# Altium schematic sheet Regulator_9V0_to_5V0.SchDoc(Regulator_9V0_to_5V0)
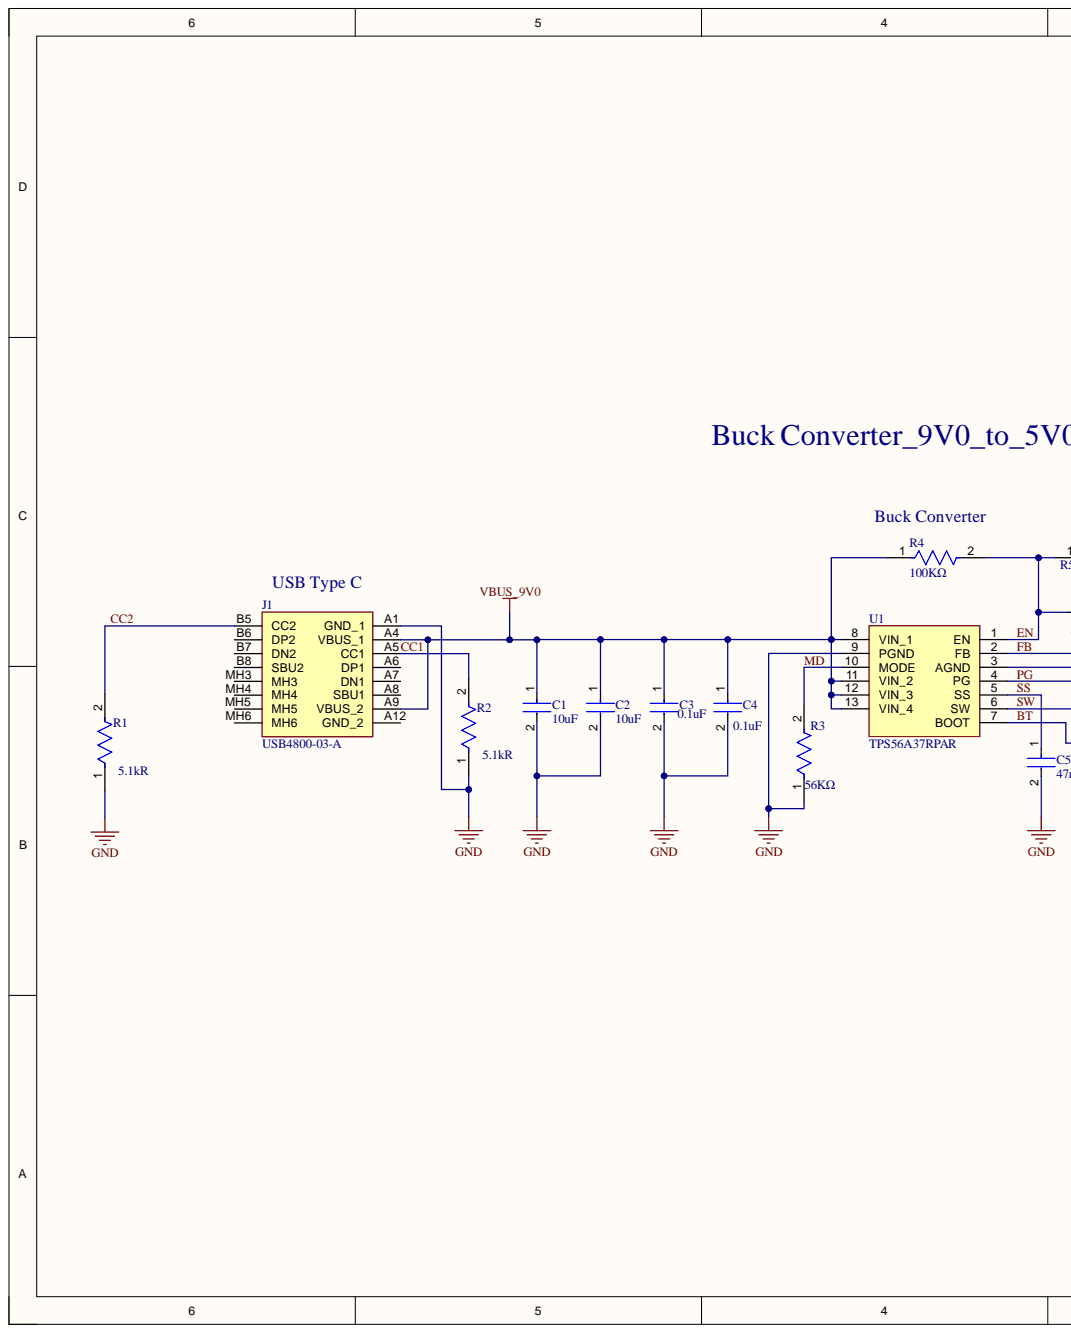
[diagram p0: Regulator_9V0_to_5V0.SchDoc, left]
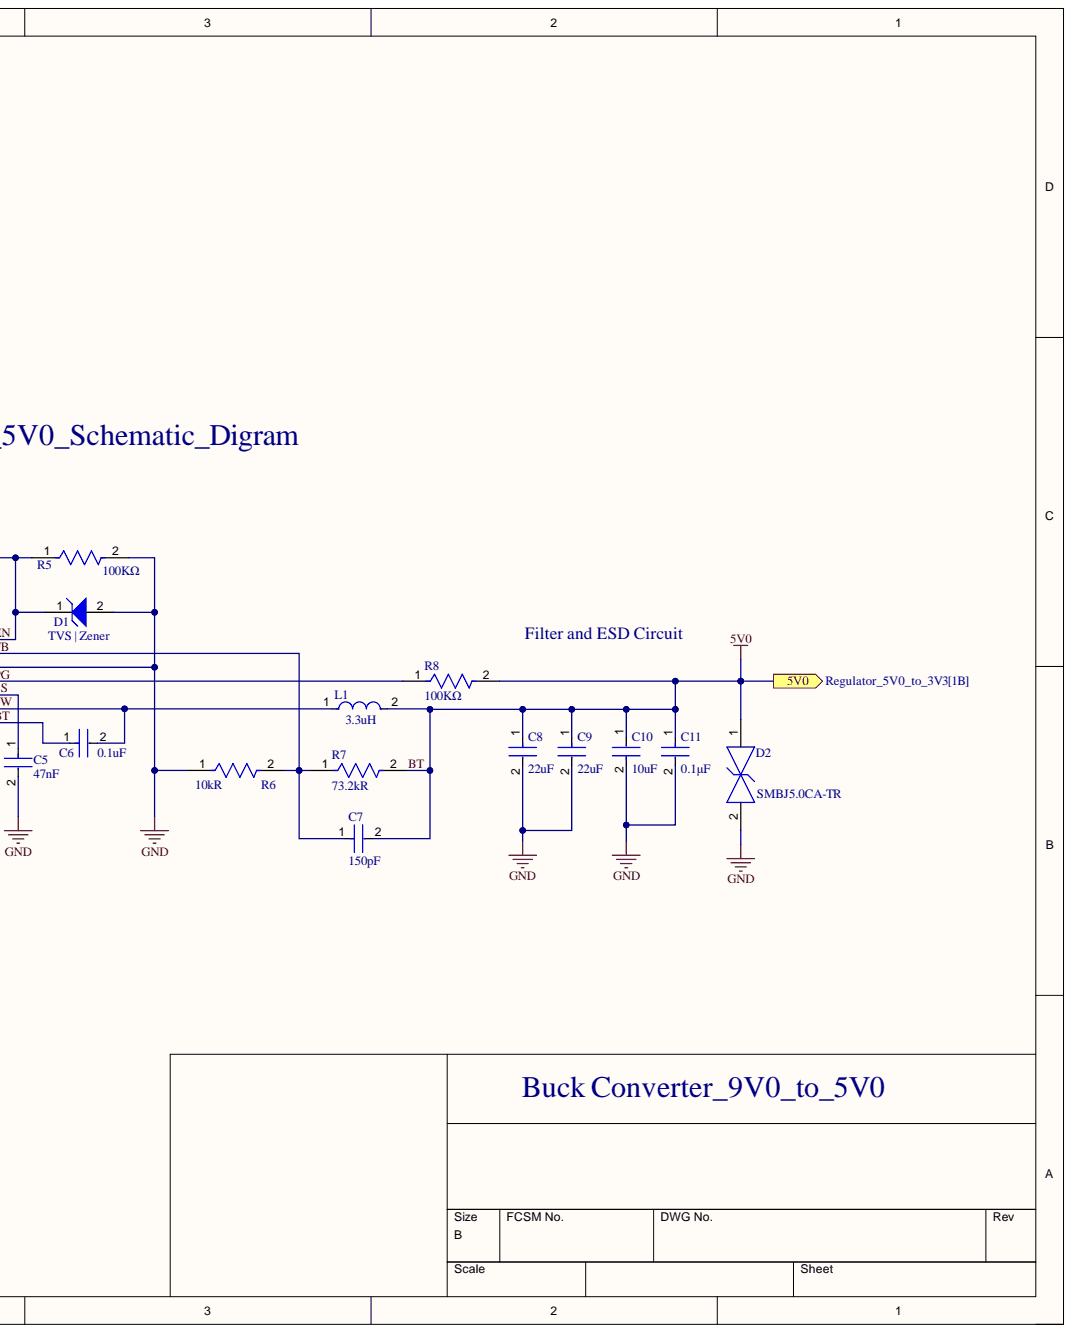
[diagram p1: Regulator_9V0_to_5V0.SchDoc, right]

## Parts
C1  Capacitance: 10uF  [FP-CL21-IPC_C]  (hidden comment: CL21A106KOQNNNG)
C2  Capacitance: 10uF  [FP-CL21-IPC_C]  (hidden comment: CL21A106KOQNNNG)
C3  Capacitance: 0.1uF  [FP-0402-L_1_0_1-W_0_5_0_1-IPC_B]  (hidden comment: 0402YD104KAT2A)
C4  Capacitance: 0.1uF  [FP-0402-L_1_0_1-W_0_5_0_1-IPC_B]  (hidden comment: 0402YD104KAT2A)
C5  Capacitance: 47nF  [FP-CL579-IPC_C]  (hidden comment: CL05B473KO5NNNC)
C6  Capacitance: 0.1uF  [FP-0402-L_1_0_1-W_0_5_0_1-IPC_B]  (hidden comment: 0402YD104KAT2A)
C7  Capacitance: 150pF  [FP-C1005-050-0_05-IPC_B]  (hidden comment: C1005NP01H151J050BA)
C8  Capacitance: 22uF  [FP-CL10-IPC_B]  (hidden comment: CL10A226MO7JZNC)
C9  Capacitance: 22uF  [FP-CL10-IPC_B]  (hidden comment: CL10A226MO7JZNC)
C10  Capacitance: 10uF  [FP-C1608-080-0_1-IPC_A]  (hidden comment: C1608X5R1A106M080AC)
C11  Capacitance: 0.1μF  [FP-C0603C-CF-MFG]  (hidden comment: C0603C104K8RACTU)
D1  ESD5Z6.0T1G  [ONSC-SOD-523-2-502-01_V]  (value not drawn)
D2  SMBJ5.0CA-TR  [FP-SMB-CA-MFG]
J1  USB4800-03-A  [USB480003A]
L1  Inductance: 3.3uH  [FP-IHLP-2020CZ-FP_1_905x2-MFG]  (hidden comment: IHLP2020CZER3R3M11)
R1  Resistance: 5.1kR  [FP-CRCW0402-e3-IPC_C]  (hidden comment: CRCW04025K10FKED)
R2  Resistance: 5.1kR  [FP-CRCW0402-e3-IPC_C]  (hidden comment: CRCW04025K10FKED)
R3  value 56KΩ  Resistance: 56KΩ  [FP-CRGCQ0402-IPC_C]  (hidden comment: CRGCQ0402F56K)
R4  value 100KΩ  Resistance: 100KΩ  [FP-CRGP0402-IPC_C]  (hidden comment: CRGP0402F100K)
R5  value 100KΩ  Resistance: 100KΩ  [FP-CRGP0402-IPC_C]  (hidden comment: CRGP0402F100K)
R6  Resistance: 10kR  [FP-AC0402-MFG]  (hidden comment: AC0402FR-0710KL)
R7  Resistance: 73.2kR  [FP-RC1005-IPC_B]  (hidden comment: RC1005F7322CS)
R8  value 100KΩ  Resistance: 100KΩ  [FP-CRGP0402-IPC_C]  (hidden comment: CRGP0402F100K)
U1  TPS56A37RPAR  [TPS56A37RPAR]

## Ports
5V0

## Nets
GND: C1.2 C2.2 C3.2 C4.2 C5.2 C8.2 C9.2 C10.2 C11.2 D1.2 D2.2 J1.A1(GND_1) R1.1 R2.1 R3.1 R5.2 R6.1 U1.3(AGND) U1.9(PGND)
VBUS_9V0: C1.1 C2.1 C3.1 C4.1 J1.A4(VBUS_1) J1.A9(VBUS_2) R4.1 U1.8(VIN_1) U1.11(VIN_2) U1.12(VIN_3) U1.13(VIN_4)
BT: C6.1 C7.2 C8.1 C9.1 C10.1 C11.1 D2.1 L1.2 R7.2 R8.2 U1.7(BOOT) ; port 5V0
CC1: J1.A5(CC1) R2.2
CC2: J1.B5(CC2) R1.2
EN: D1.1 R4.2 R5.1 U1.1(EN)
FB: C7.1 R6.2 R7.1 U1.2(FB)
MD: R3.2 U1.10(MODE)
PG: R8.1 U1.4(PG)
SS: C5.1 U1.5(SS)
SW: C6.2 L1.1 U1.6(SW)

Not connected: J1 A6(DP1) A7(DN1) A8(SBU1) A12(GND_2) B6(DP2) B7(DN2) B8(SBU2) MH3 MH4 MH5 MH6

## Library data of the parts
C1 C2 = CMP-13271-002240-1: CL21 Series 0805 10uF 16V ±10% Tolerance X5R Multilayer Ceramic Chip Capacitor; Case Code (Imperial): 0805; Case Code (Metric): 2012; Case/Package: 0805; Component Kind: Standard; Depth: 1.25mm; Dielectric: X5R; Height: 1.25mm; Length: 2mm; Library Name: Altium Content Vault; Material: Ceramic; Max Operating Temperature: 85°C; Min Operating Temperature: -55°C; Mount: Surface Mount; Package Quantity: 3000; Packaging: Tape and Reel; Thickness: 0.053inch; Tolerance: 10%; Voltage: 16V; Voltage Rating: 16V; Width: 0.049inch
C3 C4 C6 = CMP-2008-02842-2: General Purpose Ceramic Capacitor, 0402, 10uF, 10%, X5R, 15%, 16V; Dissipation Factor: 3.5%; Case Code (Imperial): 0402; Case Code (Metric): 1005; Case/Package: 0402; Component Kind: Standard; Construction: Flat; Contact Plating: Tin; Depth: 0.5mm; Dielectric: X5R; Height: 0.56mm; Lead Free: Lead Free; Length: 1mm; Library Name: Altium Content Vault; Max Operating Temperature: 85°C; Min Operating Temperature: -55°C; Mount: Surface Mount; Package Quantity: 1; Packaging: Tape and Reel; Radiation Hardening: No; REACH SVHC: No SVHC; RoHS Compliant: Yes; Series: -; Termination: SMD/SMT; Thickness: 0.022inch; Tolerance: 10%; Voltage: 16V; Voltage Rating: 16V; Voltage Rating (DC): 16V; Width: 0.02inch
C5 = CMP-13271-000643-1: Cap Ceramic 47nF 16V X7R ±10% SMD 0402 +125°C Paper T/R; Case Code (Imperial): 0402; Case Code (Metric): 1005; Case/Package: 0402; Component Kind: Standard; Contact Plating: Tin; Depth: 0.5mm; Dielectric: X7R; Height: 0.5mm; Lead Free: Lead Free; Length: 1mm; Library Name: Altium Content Vault; Max Operating Temperature: 125°C; Min Operating Temperature: -55°C; Mount: Surface Mount; Package Quantity: 1; Packaging: Tape and Reel; Radiation Hardening: No; Thickness: 0.022inch; Tolerance: 10%; Voltage: 16V; Voltage Rating: 16V; Voltage Rating (DC): 16V; Width: 0.02inch
C7 = CMP-08246-007033-1: Multilayer Ceramic Capacitors 150pF ±5% 50V NP0 SMD 0402; Dielectric: C0G; Case Code (Imperial): 0402; Case Code (Metric): 1005; Case/Package: 0402; Component Kind: Standard; Features: High Temperature; Height: 0.5mm; Length: 0.039inch; Library Name: Altium Content Vault; Max Operating Temperature: 150°C; Min Operating Temperature: -55°C; Mount: Surface Mount; Package Quantity: 10000; Packaging: Tape and Reel; RoHS Compliant: Yes; Series: C; Termination: SMD/SMT; Thickness: 0.022inch; Tolerance: 5%; Voltage Rating: 50V; Voltage Rating (DC): 50V; Weight: 2.3E-05oz; Width: 0.02inch
C8 C9 = CMP-13271-002755-1: Cap Ceramic 22uF 16V X5R ±20% Pad SMD 0603 +85°C T/R; Case Code (Imperial): 0603; Case Code (Metric): 1608; Case/Package: 0603; Component Kind: Standard; Library Name: Altium Content Vault; Max Operating Temperature: 85°C; Min Operating Temperature: -55°C; Mount: Surface Mount; Packaging: Tape and Reel; Tolerance: 20%; Voltage Rating: 16V
C10 = CMP-2000-04980-2: Multilayer Ceramic Capacitors 10μF ±20% 10V X5R SMD 0603; Case Code (Imperial): 0603; Case Code (Metric): 1608; Case/Package: 0603; Component Kind: Standard; Construction: Flat; Depth: 0.8mm; Dielectric: X5R; Dielectric Material: Ceramic; Height: 0.8mm; Lead Free: Lead Free; Length: 1.6mm; Library Name: Altium Content Vault; Max Operating Temperature: 85°C; Min Operating Temperature: -55°C; Mount: Surface Mount; Number of Pins: 2; Package Quantity: 1; Packaging: Tape and Reel; Radiation Hardening: No; REACH SVHC: No SVHC; Resistor Type: Ceramic; RoHS Compliant: Yes; Series: C; Termination: SMD/SMT; Thickness: 0.035inch; Tolerance: 20%; Voltage Rating: 10V; Voltage Rating (DC): 10V; Weight: 7.1E-05oz; Width: 0.031inch
C11 = CMP-1035-02580-3: CAP CER 0.1UF 10V X7R 0603; Manufacturer: KEMET; Automotive Grade: Grade 1; Material: Ceramic; Temperature Coefficient: 30ppm/°C; Tolerance: 0.1; Value: 0.10 μF; Automotive: Yes; Category: Cap; Component Kind: Standard; ComponentLink2Description: Datasheet; ComponentLink2URL: https://api.kemet.com/component-edge/download/datasheet/C0603C100J5GACAUTO.pdf; Device Class L1: Passive Components; Device Class L2: Capacitors; Device Class L3: Ceramic Capacitors; Digikey Description: CAP CER 0.1UF 10V X7R 0603; Height: 0.87mm; Lead Free: Yes; Library Name: Altium Content Vault; Manufacturer Part Number: C0603C104K8RACTU; Mouser Description: Multilayer Ceramic Capacitors MLCC - SMD/SMT 10volts 0.1uF X7R 10%; Octopart: https://octopart.com/c0603c104k8ractu-kemet-145075; Package: C0603C-CF; RoHS: TRUE; Temperature: -55°C to +125°C; Temperature Characteristic: X7R; Temperature Range High: +125°C; Temperature Range Low: -55°C; Voltage Rating: 10 V
D1 = CMP-1063-00101-1: Transient Voltage Suppressor, Micro-Packaged Diode for ESD Protection, 2-Pin SOD-523, Pb-Free, Tape and Reel; Manufacturer: On Semiconductor; Direction: Unidirectional; IR Max (uA): 0.01; Number of Lines: 1; PPK Max (W): 181; V(BR) Min (V): 6.8; VRWM Max (V): 6; Component Kind: Standard; ComponentLink1Description: Manufacturer URL; ComponentLink1URL: http://www.onsemi.com/; ComponentLink2Description: Datasheet; ComponentLink2URL: http://www.onsemi.cn/pub_link/Collateral/ESD5Z2.5T1-D.PDF; ComponentLink3Description: Package Specification; ComponentLink3URL: http://www.onsemi.com/pub_link/Collateral/502-01.PDF; DatasheetVersion: Rev. 10; Library Name: Altium Content Vault; Mounting Technology: Surface Mount; PackageDescription: 2-Pin SOD, Body 1.2 x 0.8 mm; PackageReference: SOD-523-2-502-01; PackageVersion: Rev. E, 09/2010; Packing: Tape and Reel; PartNumber: ESD5Z6.0T1G; RoHS: Pb-Free
D2 = CMP-12225-000020-1: TVS DIODE 5V 13.4V SMB; Manufacturer: STMicroelectronics; Breakdown Voltage: 6.4V; Clamping Voltage: 9.2V; Direction: Bidirectional; Max Junction Temp: +150°C; Number Of Channels: 1; Peak Pulse Current: 68A; Peak Pulse Power: 600W; Standoff Height: 0.05mm; Automotive: No; Category: Diode; Component Kind: Standard; ComponentLink2Description: Datasheet; ComponentLink2URL: https://www.st.com/content/ccc/resource/technical/document/datasheet/19/78/91/39/07/cd/40/65/CD00001366.pdf/files/CD00001366.pdf/_jcr_content/translations/en.CD00001366.pdf; Device Class L1: Circuit Protection; Device Class L2: TVS Diodes; Device Class L3: unset; Digikey Description: TVS DIODE 5V 13.4V SMB; Height: 2.65mm; Lead Free: Yes; Library Name: Altium Content Vault; Manufacturer Part Number: SMBJ5.0CA-TR; Mouser Description: TVS Diodes - Transient Voltage Suppressors 600W 5.0V Bidirect; Octopart: https://octopart.com/smbj5.0ca-tr-stmicroelectronics-347080; Package: SMB-CA; Reverse Standoff Voltage: 5V; RoHS: TRUE; Temperature: -55°C to +150°C; Temperature Range High: +150°C; Temperature Range Low: -55°C
J1 = USB4800-03-A: Connector; Manufacturer_Name: GCT (GLOBAL CONNECTOR TECHNOLOGY); Component Kind: Standard; Datasheet Link: https://gct.co/connector/usb4800; Height: 5.58mm; Library Name: USB480003A.IntLib; Manufacturer_Part_Number: USB4800-03-A; Mouser Part Number: 640-USB4800-03-A; Mouser Price/Stock: https://www.mouser.co.uk/ProductDetail/GCT/USB4800-03-A?qs=vvQtp7zwQdMkfG8vViEZoQ%3D%3D
L1 = CMP-02424-000463-1: Fixed Inductors Commercial Low DCR Series 3.3uH 5.5A 40.4mΩ 20%; DC Resistance (DCR): 40.4mR; Max DC Current: 7A; Self Resonant Frequency: 29MHz; Shielding: Shielded; Test Frequency: 100kHz; Case/Package: 2020; Component Kind: Standard; Contact Plating: Tin; Current Rating: 5.5A; Depth: 5.43mm; Height: 3mm; Height - Seated (Max): 0.118inch; Inductance Tolerance: 20%; Lead Free: Lead Free; Length: 5.74mm; Library Name: Altium Content Vault; Max Operating Temperature: 125°C; Military Standard: Not; Min Operating Temperature: -55°C; Mount: Surface Mount; Package Quantity: 2000; Packaging: Tape and Reel; Radiation Hardening: No; RMS Current (Irms): 5.5A; Saturation Current: 7A; Termination: SMD/SMT; Tolerance: 20%; Width: 0.204inch
R1 R2 = CMP-1011-00590-4: RES Thick Film, 5.1kΩ, 1%, 0.063W, 100ppm/°C, 0402; Max Power Dissipation: 63mW; Voltage Rating: 50V; Case Code (Imperial): 0402; Case Code (Metric): 1005; Case/Package: 0402; Component Kind: Standard; Composition: Thick Film; Contact Plating: Tin; Depth: 0.5mm; Features: Automotive AEC-Q200; Height: 0.35mm; Lead Free: Lead Free; Length: 1mm; Library Name: Altium Content Vault; Max Operating Temperature: 155°C; Military Standard: Not; Min Operating Temperature: -55°C; Number of Terminations: 2; Package Quantity: 10; Packaging: Tape and Reel; Power Rating: 63mW; Radiation Hardening: No; Temperature Coefficient: 100ppm/°C; Termination: SMD/SMT; Tolerance: 1%; Voltage Rating (DC): 50V; Weight: 2.3E-05oz; Width: 0.02inch
R3 = CMP-03211-009184-1: CRGCQ 0402 56K 1%; Manufacturer: TE Connectivity; Power Rating: 0.0625W; Temperature Coefficient: ±100ppm/°C; Tolerance: 0.01; Voltage Rating: 50V; Ambient Temperature Range High: +70°C; Automotive: Yes; Category: Res; Component Kind: Standard; ComponentLink2Description: Datasheet; ComponentLink2URL: https://www.te.com/commerce/DocumentDelivery/DDEController?Action=showdoc&DocId=Data+Sheet%7F1773204-3%7F1%7Fpdf%7FEnglish%7FENG_DS_1773204-3_1.pdf%7F4-2176347-1092; Composition: Thick Film; Device Class L1: Passive Components; Device Class L2: Resistors; Device Class L3: Chip SMD Resistors; Digikey Description: CRGCQ 0402 56K 1%; Height: 0.4mm; Ipc Land Pattern Name: RESC10050X35; Lead Free: Yes; Library Name: Altium Content Vault; Manufacturer Part Number: CRGCQ0402F56K; Octopart: https://octopart.com/crgcq0402f56k-te+connectivity-91017978; Package: CRGCQ0402; RoHS: TRUE; Series: CRGCQ; Temperature: -55°C to +155°C; Temperature Range High: +155°C; Temperature Range Low: -55°C
R4 R5 R8 = CMP-03211-001392-1: SMD Precision Pulse Thick Film Chip Resistor; Manufacturer: TE; Power Rating: 0.125W; Temperature Coefficient: ±100ppm/°C; Tolerance: 0.01; Voltage Rating: 50V; Automotive: No; Category: Res; Component Kind: Standard; ComponentLink2Description: Datasheet; ComponentLink2URL: https://www.mouser.ca/datasheet/2/418/NG_DS_9-1773463-9_A-1358566.pdf; Composition: Thick Film; Device Class L1: Passive Components; Device Class L2: Resistors; Device Class L3: Chip SMD Resistors; Digikey Description: SMD Precision Pulse Thick Film Chip Resistor; Height: 0.4mm; Ipc Land Pattern Name: RESC11050X35; Lead Free: Yes; Library Name: Altium Content Vault; Manufacturer Part Number: CRGP0402F100K; Octopart: https://octopart.com/crgp0402f100k-te+connectivity-91018658; Package: CRGP0402; RoHS: TRUE; Series: CRGP; Temperature: -55°C to +155°C; Temperature Range High: +155°C; Temperature Range Low: -55°C
R6 = CMP-2002-01790-3: RES 10kΩ ±1% 0.063W 0402; Max Power Dissipation: 63mW; Power Rating: 62.5mW; Temperature Coefficient: 100ppm/°C; Voltage Rating: 50V; Case Code (Imperial): 0402; Case Code (Metric): 1005; Case/Package: 0402; Component Kind: Standard; Construction: Rectangular; Depth: 0.5mm; Features: Moisture Resistant; Height: 0.015inch; Lead Free: Lead Free; Length: 0.039inch; Library Name: Altium Content Vault; Max Operating Temperature: 155°C; Military Standard: Not; Min Operating Temperature: -55°C; Number of Terminations: 2; Packaging: Tape and Reel; Resistor Type: Thick Film; RoHS Compliant: Yes; Series: AC; Size Code: 0402; Termination: SMD/SMT; Tolerance: 1%; Width: 0.02inch
R7 = CMP-13261-004133-1: Res Thick Film 0402 73.2kΩ 1% 0.063W ±100ppm/°C Molded SMD T/R; Max Power Dissipation: 63mW; Voltage Rating (DC): 50V; Case Code (Imperial): 0402; Case Code (Metric): 1005; Case/Package: 0402; Component Kind: Standard; Composition: Thick Film; Contact Plating: Tin; Depth: 0.5mm; Features: Moisture Resistant; Height: 0.016inch; Lead Free: Lead Free; Length: 1mm; Library Name: Altium Content Vault; Max Operating Temperature: 155°C; Military Standard: Not; Min Operating Temperature: -55°C; Number of Terminations: 2; Package Quantity: 1; Packaging: Tape and Reel; Power Rating: 63mW; Radiation Hardening: No; Temperature Coefficient: 100ppm/°C; Tolerance: 1%; Width: 0.02inch
U1 = TPS56A37RPAR: Integrated Circuit; Manufacturer_Name: Texas Instruments; Arrow Part Number: TPS56A37RPAR; Arrow Price/Stock: https://www.arrow.com/en/products/tps56a37rpar/texas-instruments?utm_currency=USD&region=nac; Component Kind: Standard; Datasheet Link: https://www.ti.com/lit/ds/symlink/tps56a37.pdf?ts=1708310049261&ref_url=https%253A%252F%252Fwww.ti.com%252Fproduct%252FTPS56A37; Height: 1mm; Library Name: TPS56A37RPAR.IntLib; Manufacturer_Part_Number: TPS56A37RPAR; Mouser Part Number: 595-TPS56A37RPAR; Mouser Price/Stock: https://www.mouser.co.uk/ProductDetail/Texas-Instruments/TPS56A37RPAR?qs=dbcCsuKDzFV3BmG4b9YeGQ%3D%3D
